# Altium schematic sheet SignalProcessing.SchDoc
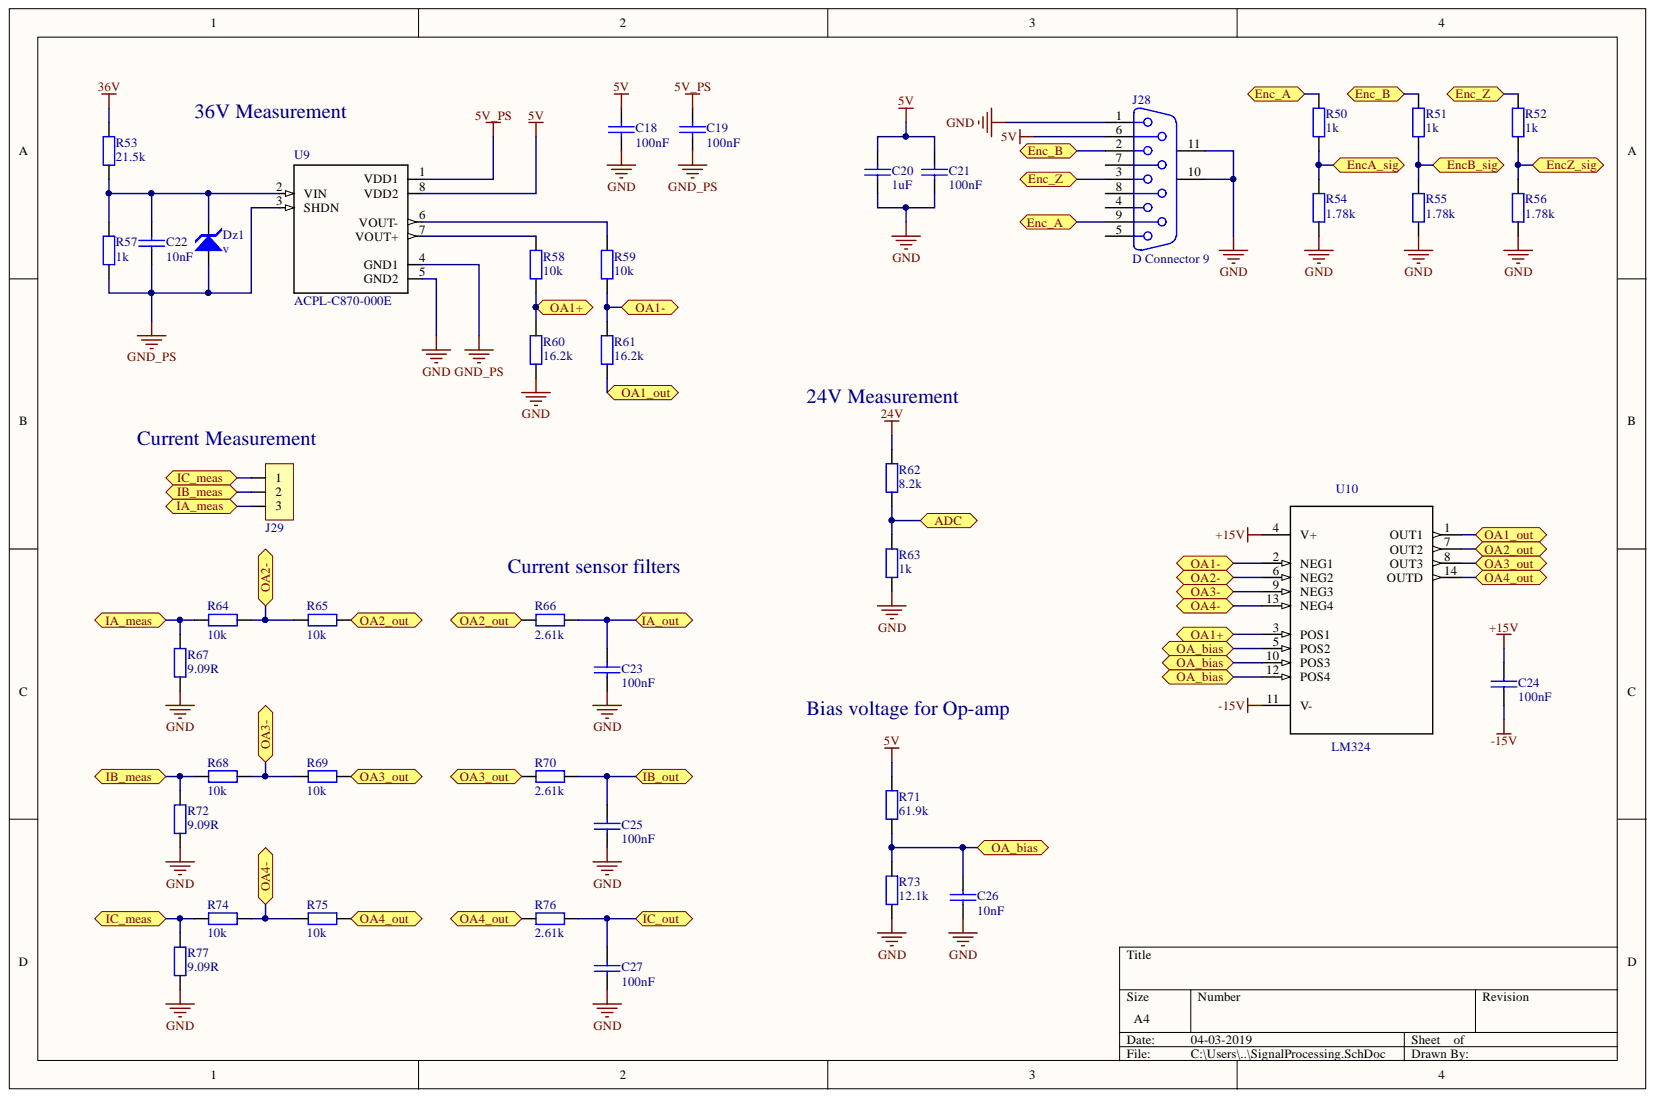
[diagram p0: SignalProcessing.SchDoc]

## Parts
C18  100nF  [1206]
C19  100nF  [1206]
C20  1uF  [1206]
C21  100nF  [1206]
C22  10nF  [1206]
C23  100nF  [1206]
C24  100nF  [1206]
C25  100nF  [1206]
C26  10nF  [1206]
C27  100nF  [1206]
Dz1  v  [Diodo]
J28  D Connector 9  [DSUB1.385-2H9]
J29  Cleva 2 vias  [Con3_KK_256]  (value not drawn)
R50  1k  [1206]
R51  1k  [1206]
R52  1k  [1206]
R53  21.5k  [1206]
R54  1.78k  [1206]
R55  1.78k  [1206]
R56  1.78k  [1206]
R57  1k  [1206]
R58  10k  [1206]
R59  10k  [1206]
R60  16.2k  [1206]
R61  16.2k  [1206]
R62  8.2k  [1206]
R63  1k  [1206]
R64  10k  [1206]
R65  10k  [1206]
R66  2.61k  [1206]
R67  9.09R  [1206]
R68  10k  [1206]
R69  10k  [1206]
R70  2.61k  [1206]
R71  61.9k  [1206]
R72  9.09R  [1206]
R73  12.1k  [1206]
R74  10k  [1206]
R75  10k  [1206]
R76  2.61k  [1206]
R77  9.09R  [1206]
U9  ACPL-C870-000E  [SOIC127P1150X360-8N]
U10  LM324  [SOIC_14]

## Ports
ADC, ENC_A, ENC_B, ENC_Z, ENCA_SIG, ENCB_SIG, ENCZ_SIG, IA_MEAS, IA_OUT, IB_MEAS, IB_OUT, IC_MEAS, IC_OUT, OA1+, OA1-, OA1_OUT, OA2-, OA2_OUT, OA3-, OA3_OUT, OA4-, OA4_OUT, OA_BIAS

## Nets
5V: C18.1 C20.2 C21.2 J28.6 R71.2 U9.8(VDD2)
5V_PS: C19.1 U9.1(VDD1)
24V: R62.1
36V: R53.2
+15V: C24.1 U10.4(V+)
-15V: C24.2 U10.11(V-)
GND: C18.2 C20.1 C21.1 C23.2 C25.2 C26.2 C27.2 J28.1 J28.10 J28.11 R54.2 R55.2 R56.2 R60.2 R63.1 R67.2 R72.2 R73.2 R77.2 U9.5(GND2)
GND_PS: C19.2 C22.2 Dz1.1 R57.2 U9.3(SHDN) U9.4(GND1)
ADC: R62.2 R63.2 ; port ADC
OA2-: R64.2 R65.1 U10.6(NEG2) ; port OA2-
OA3-: R68.2 R69.1 U10.9(NEG3) ; port OA3-
OA4-: R74.2 R75.1 U10.13(NEG4) ; port OA4-
NetC22_1: C22.1 Dz1.2 R53.1 R57.1 U9.2(VIN)
NetC23_1: C23.1 R66.1 ; port IA_OUT
NetC25_1: C25.1 R70.1 ; port IB_OUT
NetC26_1: C26.1 R71.1 R73.1 U10.5(POS2) U10.10(POS3) U10.12(POS4) ; port OA_BIAS
NetC27_1: C27.1 R76.1 ; port IC_OUT
NetJ28_2: J28.2 R51.2 ; port ENC_B
NetJ28_3: J28.3 R52.2 ; port ENC_Z
NetJ28_9: J28.9 R50.2 ; port ENC_A
NetJ29_1: J29.1 R74.1 R77.1 ; port IC_MEAS
NetJ29_2: J29.2 R68.1 R72.1 ; port IB_MEAS
NetJ29_3: J29.3 R64.1 R67.1 ; port IA_MEAS
NetR50_1: R50.1 R54.1 ; port ENCA_SIG
NetR51_1: R51.1 R55.1 ; port ENCB_SIG
NetR52_1: R52.1 R56.1 ; port ENCZ_SIG
NetR58_1: R58.1 R60.1 U10.3(POS1)
NetR58_2: R58.2 U9.7(VOUT+)
NetR59_1: R59.1 R61.1 U10.2(NEG1)
NetR59_2: R59.2 U9.6(VOUT-)
NetR61_2: R61.2 U10.1(OUT1) ; port OA1_OUT
NetR65_2: R65.2 R66.2 U10.7(OUT2) ; port OA2_OUT
NetR69_2: R69.2 R70.2 U10.8(OUT3) ; port OA3_OUT
NetR75_2: R75.2 R76.2 U10.14(OUTD) ; port OA4_OUT

Not connected: J28 4 5 7 8

## Library data of the parts
C18-C27 = Condensador: Component Kind: Standard; Description: Condensador; Library Name: SCH_Lib.SchLib
Dz1 = Zener: Component Kind: Standard; Library Name: SCH_Lib.SchLib
J28 = D Connector 9: Receptacle Assembly, 9 Position, Right Angle; PackageDocument: O; Positions: 9; Component Kind: Standard; DatasheetDocument: 1-Sep-1999; Gender: Receptacle; LatestRevisionDate: 17-Jul-2002; LatestRevisionNote: Re-released for DXP Platform.; Library Name: Miscellaneous Connectors.IntLib; Orientation: Right Angle; PackageReference: 788750; Published: 15-Jun-2001; Signal Integrity: Connector; Publisher: Altium Limited
J29 = Con_3: Component Kind: Standard; Library Name: SCH_Lib.SchLib
R50-R77 = Resistencia: Potencia: 1/4W; Component Kind: Standard; Description: Resistencia; Library Name: SCH_Lib.SchLib
U9 = ACPL-C870-000E: Isolation Amplifier [Broadcom] ACPL-C870-000E Isolation Amplifier; MF: Broadcom Limited; Component Kind: Standard; Digi-Key_Part_Number: 516-2578-5-ND; Digi-Key_Purchase_URL: https://www.digikey.dk/product-detail/en/broadcom-limited/ACPL-C870-000E/516-2578-5-ND/3523705?utm_source=snapeda&utm_medium=aggregator&utm_campaign=symbol; Library Name: SCH_Lib.SchLib; MP: ACPL-C870-000E; Package: SO-8 Broadcom; SnapEDA_Link: https://www.snapeda.com/parts/ACPL-C870-000E/Broadcom%20Limited/view-part/?ref=snap
U10 = LMC6484IM_1: 14 pin CMOS Quad Rail to Rail Input and Output Operational Amplifier 55 per tube; MF: Texas Instruments; Availability: Unavailable; Component Kind: Standard; Library Name: SCH_Lib.SchLib; MP: LMC6484IM; Package: SOIC-14 Texas Instruments; Price: None; SnapEDA_Link: https://www.snapeda.com/parts/LMC6484IM/Texas%20Instruments/view-part/?ref=snap
